# Altium schematic sheet FanMux.SchDoc(FanMux)
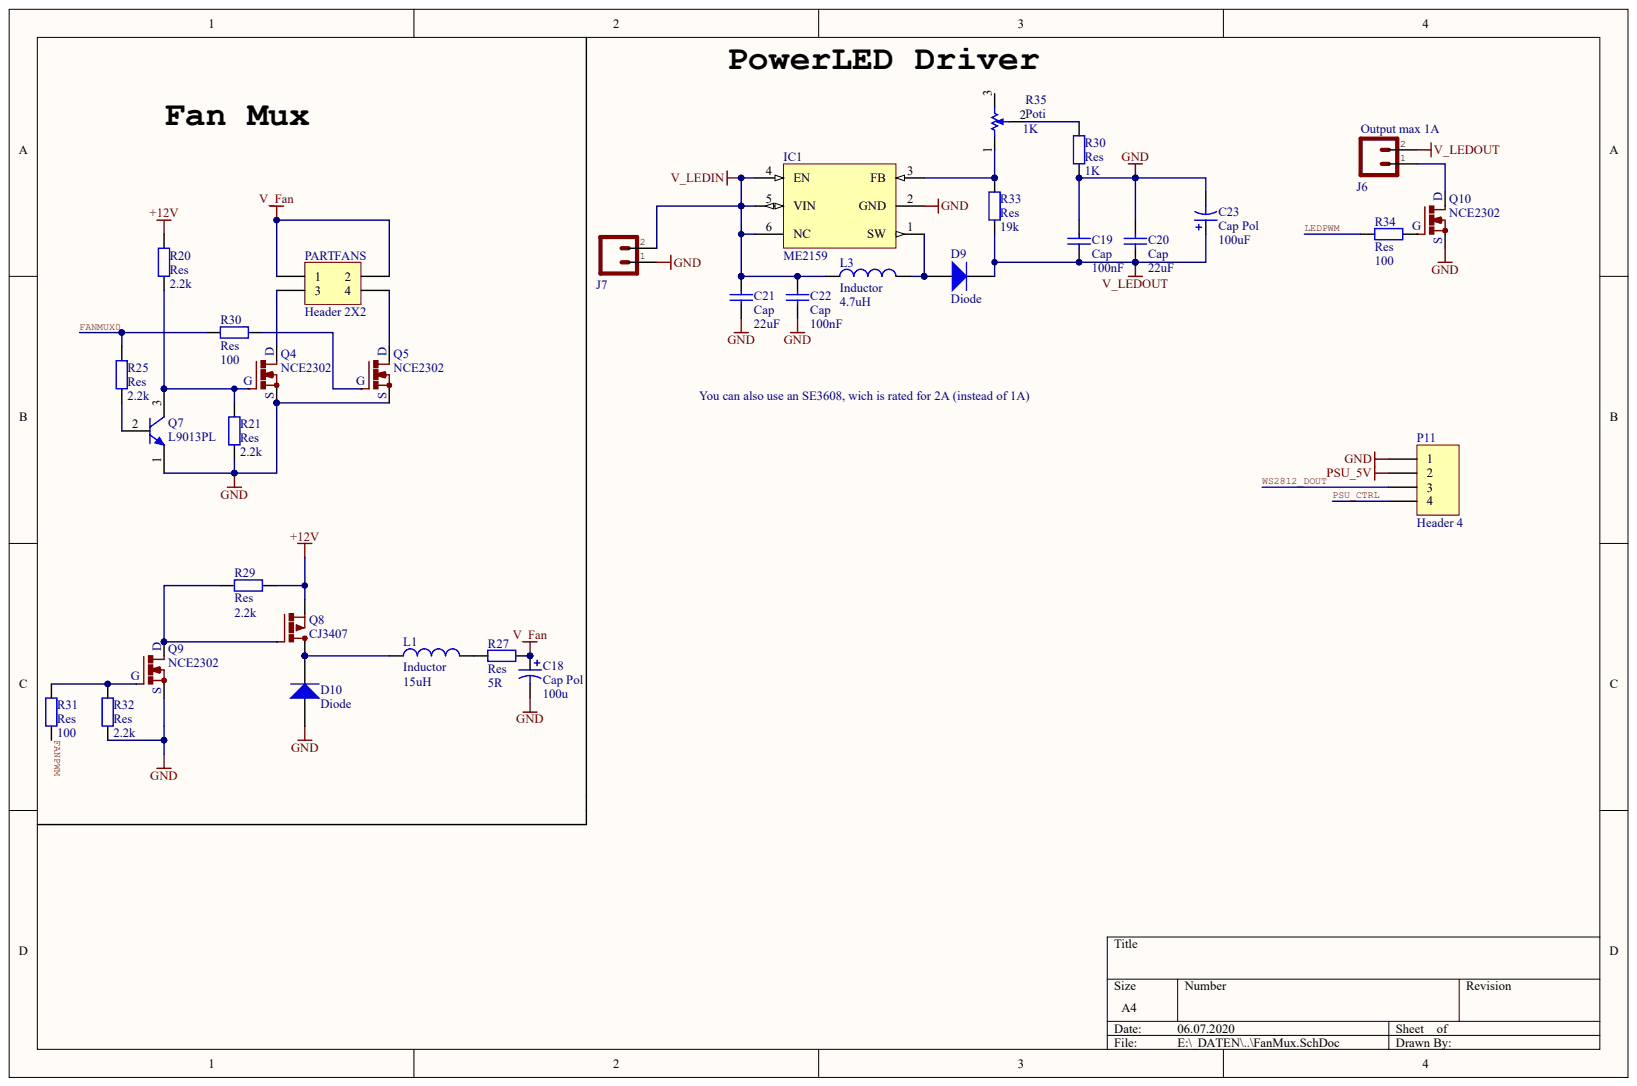
[diagram p0: FanMux.SchDoc]

## Parts
C18  Cap Pol  value 100u
C19  Cap  value 100nF
C20  Cap  value 22uF
C21  Cap  value 22uF
C22  Cap  value 100nF
C23  Cap Pol  value 100uF
D9  Diode
D10  Diode
IC1  ME2159
J6  Terminalblock X2  (value not drawn)
J7  Terminalblock X2  (value not drawn)
L1  Inductor  value 15uH
L3  Inductor  value 4.7uH
P11  Header 4
PARTFANS  Header 2X2
Q4  NCE2302
Q5  NCE2302
Q7  L9013PL
Q8  CJ3407
Q9  NCE2302
Q10  NCE2302
R20  Res  value 2.2k
R21  Res  value 2.2k
R25  Res  value 2.2k
R27  Res  value 5R
R29  Res  value 2.2k
R30  Res  value 1K
R30_  Res  value 100
R31  Res  value 100
R32  Res  value 2.2k
R33  Res  value 19k
R34  Res  value 100
R35  Poti

## Nets
+12V: Q8.3 R20.1 R29.1
GND: C18.1 C19.2 C20.2 C21.1 C22.1 C23.1 D10.2 IC1.2(GND) J7.1 P11.1 Q4.3 Q5.3 Q7.1 Q9.3 Q10.3 R21.1 R30.2 R32.1
PSU_5V: P11.2
V_FAN: C18.2 PARTFANS.1 PARTFANS.2 R27.2
V_LEDIN: C21.2 C22.2 IC1.4(EN) IC1.5(VIN) IC1.6(NC) J7.2 L3.1
V_LEDOUT: C19.1 C20.1 C23.2 D9.1 J6.2 R33.1
FANMUX0: R25.1 R30_.1
FANPWM: R31.2
LEDPWM: R34.1
PSU_CTRL: P11.4
WS2812_DOUT: P11.3
NetD9_2: D9.2 IC1.1(SW) L3.2
NetD10_1: D10.1 L1.1 Q8.2
NetIC1_3: IC1.3(FB) R33.2 R35.1
NetJ6_1: J6.1 Q10.2
NetL1_2: L1.2 R27.1
NetPARTFANS_3: PARTFANS.3 Q4.2
NetPARTFANS_4: PARTFANS.4 Q5.2
NetQ4_1: Q4.1 Q7.3 R20.2 R21.2
NetQ5_1: Q5.1 R30_.2
NetQ7_2: Q7.2 R25.2
NetQ8_1: Q8.1 Q9.2 R29.2
NetQ9_1: Q9.1 R31.1 R32.2
NetQ10_1: Q10.1 R34.2
NetR30_1: R30.1 R35.2

Not connected: R35 3

## Library data of the parts
D9 D10 = (no symbol name): PCB_Layout: Complies with manufacturer's recommendation.; Published: 14-Mar-2008; DatasheetVersion: Mar-2007; PackageVersion: Mar-2007; PackageReference: LWP4SG; PackageDescription: White LED; 2 Reverse Gullwing Leads, Body 1.9 x 0.875mm (Dia.xH); ComponentLink1Description: Manufacturer Link; ComponentLink1URL: http://www.osram-os.com/; ComponentLink2Description: Datasheet; ComponentLink2URL: http://catalog.osram-os.com/catalogue/catalogue.do;jsessionid=AD571800CED0208623E7FAFDF556DB1C?act=downloadFile&favOid=020000030001e106000200b6; Publisher: Altium Limited
L1 = (no symbol name): Code_IEC: 1005; Published: 8-Jun-2000; LatestRevisionDate: 29-May-2009; LatestRevisionNote: IPC-7351 Footprint Added.; PackageReference: 0402-A; PackageDescription: Chip Inductor; Code_JEITA: 0402; Publisher: Altium Limited
L3 = (no symbol name): Code_IEC: 1005; Value: 4.7uH; Published: 8-Jun-2000; LatestRevisionDate: 29-May-2009; LatestRevisionNote: IPC-7351 Footprint Added.; PackageReference: 0402-A; PackageDescription: Chip Inductor; Code_JEITA: 0402; Publisher: Altium Limited
Q4 Q5 Q8-Q10 = (no symbol name): Note: Pin 2 is electrically connected to pin 4.; Published: 8-Jun-2000; LatestRevisionDate: 17-Jul-2002; LatestRevisionNote: Re-released for DXP Platform.; PackageReference: TO-251AA; PackageDescription: TO, Thru-Hole, Vertical, Heatsink Mounted; 3 In-Line Leads; Pitch 2.28 mm; ComponentLink1Description: Manufacturer Link; ComponentLink1URL: http://www.irf.com/; ComponentLink2Description: Datasheet; ComponentLink2URL: http://www.irf.com/product-info/datasheets/data/irlr024n.pdf; Publisher: Altium Limited
R30_ = (no symbol name): Value: 100; Published: 8-Jun-2000; LatestRevisionDate: 17-Jul-2002; LatestRevisionNote: Re-released for DXP Platform.; PackageReference: AXIAL-0.4; PackageDescription: Axial Device, Thru-Hole; 2 Leads; 0.4 in Pin Spacing; Publisher: Altium Limited
C18-C23 IC1 J6 J7 P11 PARTFANS Q7 R20 R21 R25 R27 R29-R35 = (no symbol name)
  C18 C23: Published: 8-Jun-2000; LatestRevisionDate: 17-Jul-2002; LatestRevisionNote: Re-released for DXP Platform.; PackageReference: POLAR0.8; PackageDescription: Polarized Capacitor; 2 Leads
  C19-C22: Published: 8-Jun-2000; LatestRevisionDate: 17-Jul-2002; LatestRevisionNote: Re-released for DXP Platform.; PackageReference: RAD-0.3; PackageDescription: Radial Cap, Thru-Hole; 2 Leads; 0.3 in Pin Spacing
  P11 PARTFANS: LatestRevisionDate: 17-Jul-2002; LatestRevisionNote: Re-released for DXP Platform.
  Q7: Published: 8-Jun-2000; LatestRevisionDate: 15-Jan-2003; LatestRevisionNote: PCB Footprint 'BCY-W3/D4.7' replaced; DatasheetVersion: 1997; PackageVersion: Sep-1998; PackageReference: TO-92A; PackageDescription: TO, Flat Index; 3 In-Line, Axial Leads; Body Dia. 4.5mm; Leads 0.51 x 0.48 mm (max); ComponentLink1Description: Manufacturer Link; ComponentLink1URL: http://www.fairchildsemi.com/; ComponentLink2Description: Datasheet; ComponentLink2URL: http://www.fairchildsemi.com/ds/2N%2F2N3906.pdf
  R20 R21 R25 R27 R29-R34: Published: 8-Jun-2000; LatestRevisionDate: 17-Jul-2002; LatestRevisionNote: Re-released for DXP Platform.; PackageReference: AXIAL-0.4; PackageDescription: Axial Device, Thru-Hole; 2 Leads; 0.4 in Pin Spacing
  R35: Published: 17-Dec-2003; DatasheetVersion: Oct-2003; PackageReference: POT4MM-2; PackageDescription: Square Trimming Potentiometer; ComponentLink1Description: Manufacturer Link; ComponentLink1URL: http://bourns.com/2/search.html
  C18-C23 P11 PARTFANS Q7 R20 R21 R25 R27 R29-R35: Publisher: Altium Limited
